# Altium schematic sheet [4]-Arduino_Connectors.SchDoc
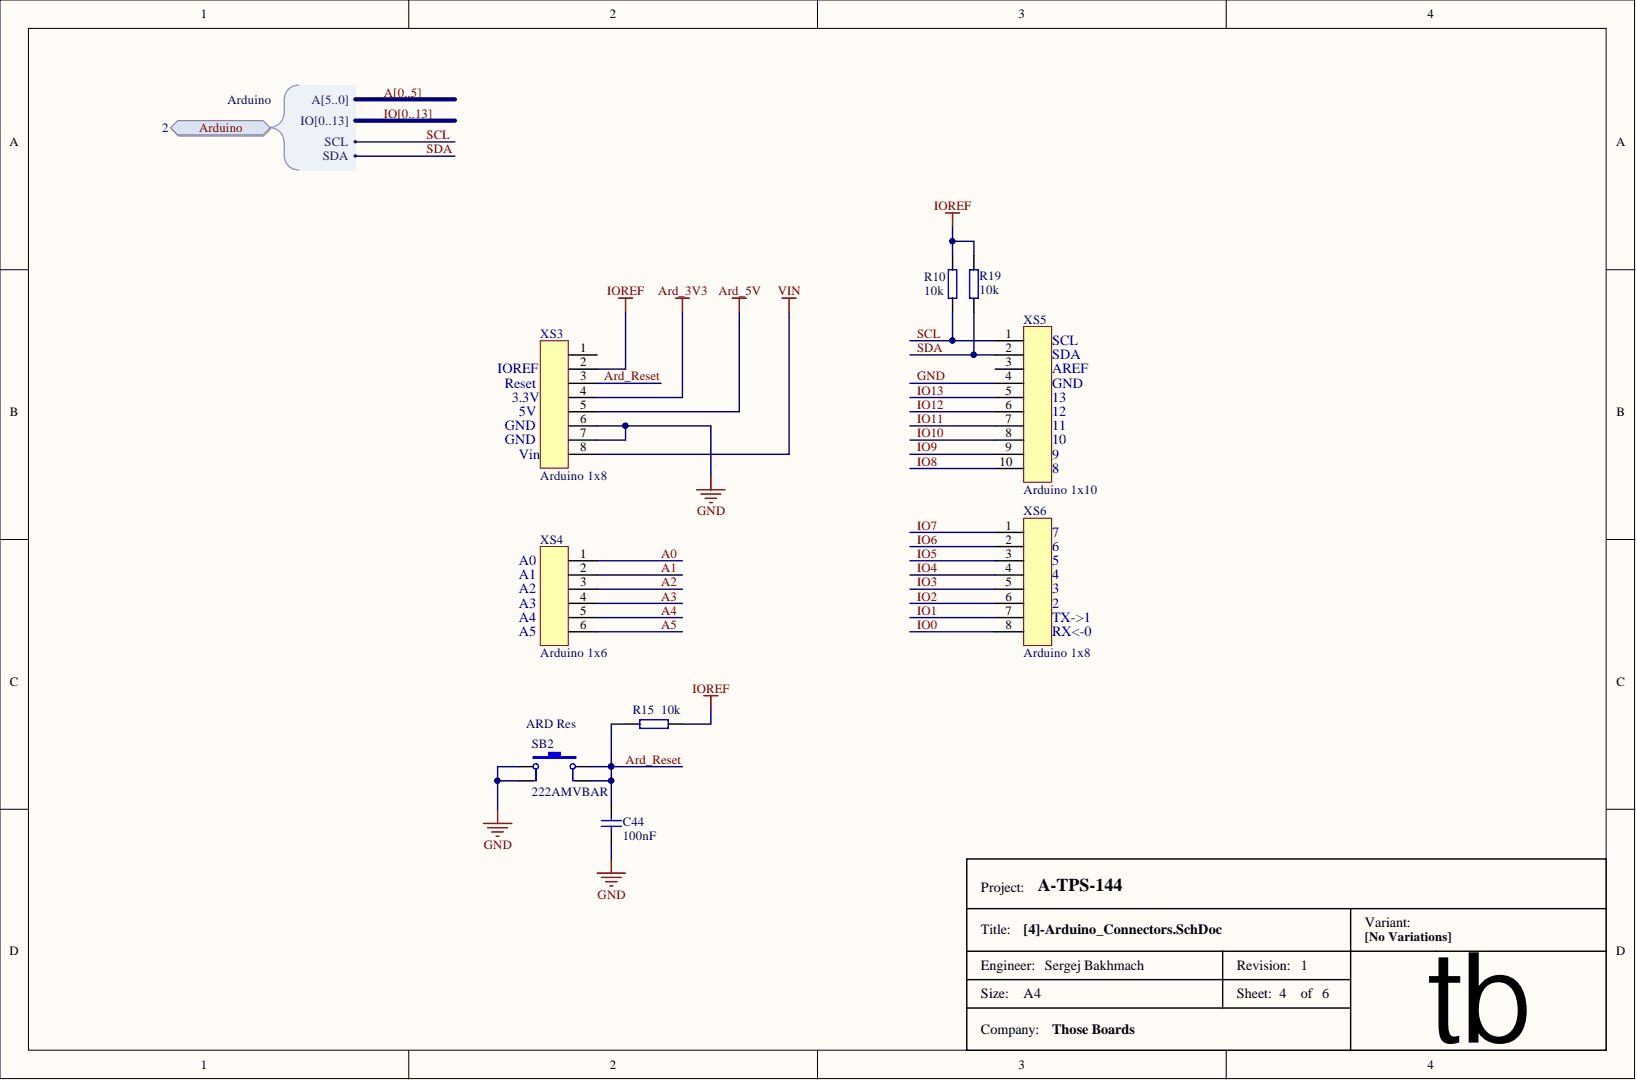
[diagram p0: [4]-Arduino_Connectors.SchDoc]

## Parts
C44  value 100nF  [C0603]  (hidden comment: CAP)
R10  value 10k  [0603_RESISTOR]  (hidden comment: Resistor)
R15  value 10k  [0603_RESISTOR]  (hidden comment: Resistor)
R19  value 10k  [0603_RESISTOR]  (hidden comment: Resistor)
SB2  ARD Res  value 222AMVBAR  Manufacturer Part: 222AMVBAR  [Key 6.2x6.2]
XS3  value Arduino 1x8  Manufacturer Part: Arduino 1x8  [Conn_Arduino_8p_2.54]  (hidden comment: conn_Arduino_8p)
XS4  value Arduino 1x6  Manufacturer Part: Arduino 1x6  [Conn_Arduino_6p_2.54]  (hidden comment: conn_Arduino_6p)
XS5  value Arduino 1x10  Manufacturer Part: Arduino 1x10  [Conn_Arduino_10p_2.54]  (hidden comment: conn_Arduino_10p)
XS6  value Arduino 1x8  Manufacturer Part: Arduino 1x8  [Conn_Arduino_8p_2.54]  (hidden comment: conn_Arduino_8p)

## Ports
ARDUINO, ARDUINO.A0, ARDUINO.A1, ARDUINO.A2, ARDUINO.A3, ARDUINO.A4, ARDUINO.A5, ARDUINO.A[5..0], ARDUINO.IO0, ARDUINO.IO1, ARDUINO.IO2, ARDUINO.IO3, ARDUINO.IO4, ARDUINO.IO5, ARDUINO.IO6, ARDUINO.IO7, ARDUINO.IO8, ARDUINO.IO9, ARDUINO.IO10, ARDUINO.IO11, ARDUINO.IO12, ARDUINO.IO13, ARDUINO.IO[0..13], ARDUINO.SCL, ARDUINO.SDA

## Nets
ARD_3V3: XS3.4
ARD_5V: XS3.5
IOREF: R10.2 R15.1 R19.2 XS3.2
VIN: XS3.8
A0: XS4.1 ; port ARDUINO  [also labelled A]
A1: XS4.2 ; port ARDUINO  [also labelled A]
A2: XS4.3 ; port ARDUINO  [also labelled A]
A3: XS4.4 ; port ARDUINO  [also labelled A]
A4: XS4.5 ; port ARDUINO  [also labelled A]
A5: XS4.6 ; port ARDUINO  [also labelled A]
ARD_RESET: C44.2 R15.2 SB2.2 SB2.4 XS3.3
GND: C44.1 SB2.1 SB2.3 XS3.6 XS3.7 XS5.4
IO0: XS6.8 ; port ARDUINO  [also labelled IO]
IO1: XS6.7 ; port ARDUINO  [also labelled IO]
IO2: XS6.6 ; port ARDUINO  [also labelled IO]
IO3: XS6.5 ; port ARDUINO  [also labelled IO]
IO4: XS6.4 ; port ARDUINO  [also labelled IO]
IO5: XS6.3 ; port ARDUINO  [also labelled IO]
IO6: XS6.2 ; port ARDUINO  [also labelled IO]
IO7: XS6.1 ; port ARDUINO  [also labelled IO]
IO8: XS5.10 ; port ARDUINO  [also labelled IO]
IO9: XS5.9 ; port ARDUINO  [also labelled IO]
IO10: XS5.8 ; port ARDUINO  [also labelled IO]
IO11: XS5.7 ; port ARDUINO  [also labelled IO]
IO12: XS5.6 ; port ARDUINO  [also labelled IO]
IO13: XS5.5 ; port ARDUINO  [also labelled IO]
SCL: R10.1 XS5.1 ; port ARDUINO
SDA: R19.1 XS5.2 ; port ARDUINO

Not connected: XS3 1; XS5 3

## Library data of the parts
C44 = CAP: Manufacturer: Vishay / Vitramon; Manufacturer Part: VJ0603Y104JXJPW1BC; Type of Component: Ceramic Capacitor; Component Kind: Standard; Manufacturer Link: http://www.vishay.com/product?docid=28548; Package / Case: 0603; Supplier: mouser; Supplier Link: http://www.mouser.com/ProductDetail/Vishay/VJ0603Y104JXJPW1BC/?qs=%2fha2pyFaduidGoO0X7aK7Xgs%2fjxfhnLiK6SRw4Lz0%252bZK3PJ8DddTrQ%3d%3d; Supplier Part: 77-VJ0603Y104JXJPBC; Library Name: RPI-VITA.IntLib
R10 R15 R19 = Resistor: Manufacturer: Vishay / Dale; Manufacturer Part: CRCW060310K0FKEA; Component Kind: Standard; Manufacturer Link: http://www.vishay.com/product?docid=20035; Package / Case: 0603; Supplier: mouser; Supplier Link: http://www.mouser.com/ProductDetail/Vishay-Dale/CRCW060310K0FKEA/?qs=sGAEpiMZZMu61qfTUdNhGyptJL5EcUtREGTsEKG7Lak%3d; Supplier Part: 71-CRCW0603-10K-E3; Type of Component: Resistor; Library Name: RPI-VITA.IntLib
SB2 = SB_Tactile: Tactile Switches; Manufacturer: E-Switch; Type of Component: Tact Switch; Component Kind: Standard; Package / Case: 6.2 x 6.2 x 5; Supplier: mouser; Supplier Link: http://www.mouser.ee/ProductDetail/CTS-Electronic-Components/222AMVBAR/?qs=sGAEpiMZZMsgGjVA3toVBB5GWLGpesfgV6sVMBFzGDw%3d; Supplier Part: 774-222AMVBAR; Library Name: RPI-VITA.IntLib
XS3 XS6 = conn_Arduino_8p: PLS-8 2.54mm Pitch, 10.5mm High; Manufacturer: China; Type of Component: Connector; Component Kind: Standard; Package / Case: Header 8; Supplier: aliexpress.com; Supplier Link: http://aliexpress.com/item/40PCS-x-8-Pin-40x-6-Pin-Stackable-Female-Shield-Header-Tall-Stacking-Kit-Special-long/689203895.html; Library Name: RPI-VITA.IntLib
XS4 = conn_Arduino_6p: PLS-6 2.54mm Pitch, 10.5mm High; Manufacturer: China; Type of Component: Connector; Component Kind: Standard; Package / Case: Header 6; Supplier: aliexpress.com; Supplier Link: http://aliexpress.com/item/40PCS-x-8-Pin-40x-6-Pin-Stackable-Female-Shield-Header-Tall-Stacking-Kit-Special-long/689203895.html; Library Name: RPI-VITA.IntLib
XS5 = conn_Arduino_10p: PLS-10 2.54mm Pitch, 10.5mm High; Manufacturer: China; Type of Component: Connector; Component Kind: Standard; Package / Case: Header 10; Supplier: aliexpress.com; Supplier Link: http://aliexpress.com/item/20PCS-2x18-Pin-2-54-mm-Stackable-Long-Legs-Femal-Header-For-Arduino-Shield/1524702262.html; Library Name: RPI-VITA.IntLib
